# Altium schematic sheet Power.SchDoc
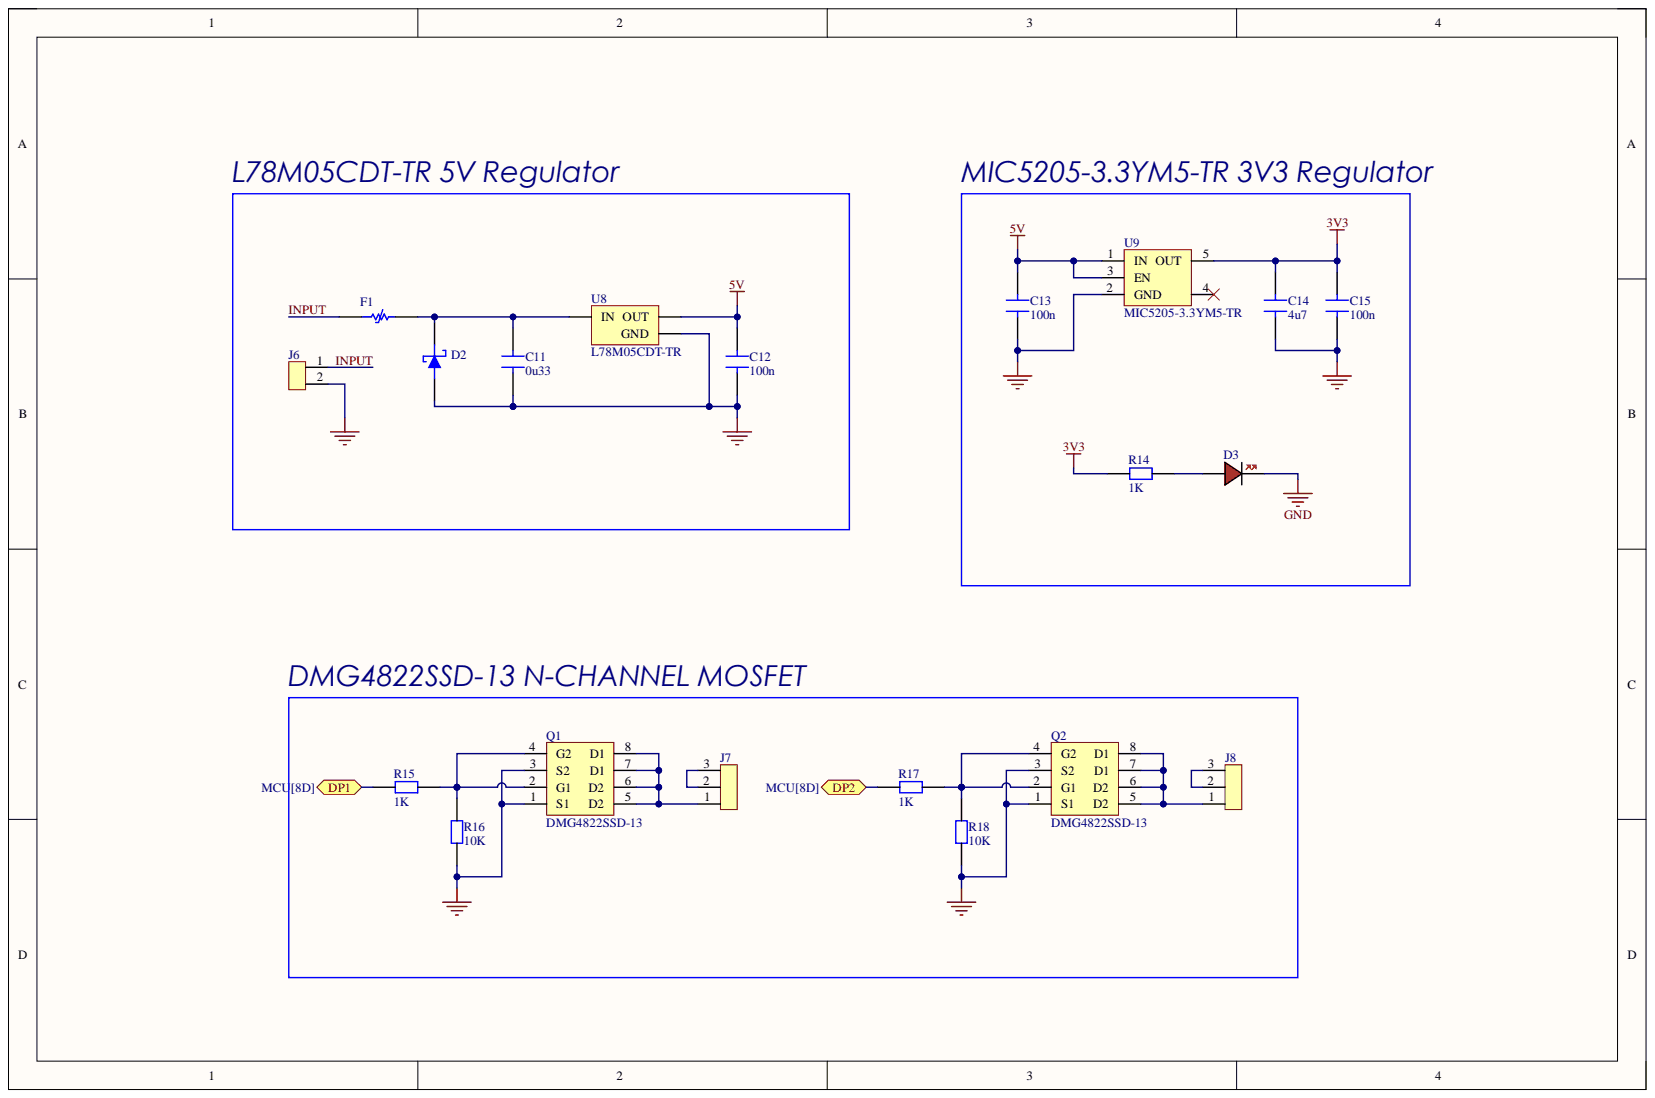
[diagram p0: Power.SchDoc]

## Parts
C11  0u33  [CAP 0805]
C12  100n  [CAP 0805]
C13  100n  [CAP 0805]
C14  4u7  [CAP 0805]
C15  100n  [CAP 0805]
D2  SMBJ12CA-13-F  [SMBJ12CA-13-F]  (value not drawn)
D3  LED 0805 RED  [LED 0805 RED]  (value not drawn)
F1  0ZCG0075FF2C  [0ZCG0075FF2C]  (value not drawn)
J6  KF301 2P Terminal  [KF301 2P]  (value not drawn)
J7  KF301 3P Terminal  [KF301 3P]  (value not drawn)
J8  KF301 3P Terminal  [KF301 3P]  (value not drawn)
Q1  DMG4822SSD-13  [DMG4822SSD-13]
Q2  DMG4822SSD-13  [DMG4822SSD-13]
R14  1K  [RES 0603]
R15  1K  [RES 0603]
R16  10K  [RES 0603]
R17  1K  [RES 0603]
R18  10K  [RES 0603]
U8  L78M05CDT-TR  [L78M05CDT-TR]
U9  MIC5205-3.3YM5-TR  [MIC5205-3.3YM5-TR]

## Ports
DP1, DP2

## Nets
3V3: C14.2 C15.2 R14.1 U9.5(OUT)
5V: C12.2 C13.2 U8.3 U9.1(IN) U9.3(EN)
GND: C11.1 C12.1 C13.1 C14.1 C15.1 D2.1 D3.K J6.2 Q1.1(S1) Q1.3(S2) Q2.1(S1) Q2.3(S2) R16.1 R18.1 U8.2 U9.2(GND)
DP1: R15.1 ; port DP1
DP2: R17.1 ; port DP2
INPUT: F1.1 J6.1
NetC11_2: C11.2 D2.2 F1.2 U8.1
NetD3_A: D3.A R14.2
NetJ7_1: J7.1 Q1.5(D2) Q1.6(D2) Q1.7(D1) Q1.8(D1)
NetJ7_2: J7.2 J7.3
NetJ8_1: J8.1 Q2.5(D2) Q2.6(D2) Q2.7(D1) Q2.8(D1)
NetJ8_2: J8.2 J8.3
NetQ1_2: Q1.2(G1) Q1.4(G2) R15.2 R16.2
NetQ2_2: Q2.2(G1) Q2.4(G2) R17.2 R18.2

Not connected: U9 4

## Library data of the parts
C11-C15 = CAP 0805 50V: Capacitor SMD 0805 50V; Component Kind: Standard; Library Name: Schlib.SchLib
D2 = SMBJ12CA-13-F: TVS DIODE 12VWM 19.9VC SMB; Manufacturer: Diodes; Breakdown Voltage: 15.3V; Capacitance: 340pF; Clamping Voltage: 19.9V; Diode Element Material: SILICON; Diode Type: ZENER; Direction: Bidirectional; Leakage Current: 5uA; Max Output Current: 30.2A; Max Power Dissipation: 600W; Min Breakdown Voltage: 13.3V; Number of Bidirectional Channels: 1; Package Body Material: Plastic; Power Line Protection: No; Reverse Standoff Voltage: 12V; Test Current: 1mA; Time @ Peak Reflow Temperature-Max (s): 5s; Case/Package: SMB; Category: TVS Diodes; Clamping Voltage-Max: 19.9V; Component Kind: Standard; ComponentLink1Description: https://datasheet.ciiva.com/34302/1542303-34302437.pdf?src-supplier=Jameco; ComponentLink1URL: https://datasheet.ciiva.com/34302/1542303-34302437.pdf?src-supplier=Jameco; Height: 2.62mm; JESD-30 Code: R-PDSO-C2; Lead Free: Contains Lead; Length: 4.57mm; Library Name: Schlib.SchLib; Manufacturer Part Number: SMBJ12CA-13-F; Max Breakdown Voltage: 15.3V; Max Operating Temperature: 150°C; Max Reverse Leakage Current: 5uA; Max Surge Current: 30.2A; Min Operating Temperature: -55°C; Moisture Sensitivity Level: 1; Mount: Surface Mount; Number of Elements: 1; Number of Pins: 2; Package Quantity: 3000; Package Shape: Rectangular; Packaging: Tape and Reel; Peak Pulse Current: 30.2A; Peak Pulse Power: 600W; Peak Reflow Temperature (Cel): 245°C; Polarity: Bidirectional; Power Dissipation: 600W; Pricing 1: 3000=0,104, 9000=0,0995, 12000=0,0985, 30000=0,0953, 45000=0,0922 (USD); Radiation Hardening: No; Reverse Breakdown Voltage: 13.3V; RoHS: Yes; RoHS Compliant: Yes; Series: SMBJ; Stock 1: 27000; Supplier 1: Future Electronics; Surface Mount: Yes; Technology: AVALANCHE; Terminal Finish: Tin; Terminal Form: C BEND; Terminal Position: DUAL; Termination: SMD/SMT; Voltage Rating (DC): 12V; Width: 3.9mm; Working Voltage: 12V
D3 = LED 0805 RED: LED 0805 RED SMD; Component Kind: Standard; Library Name: Schlib.SchLib
F1 = 0ZCG0075FF2C: PTC Resettable Fuse 0.75A(hold) 1.5A(trip) 16VDC 100A 0.8W 0.2s 0.11Ohm SMD Solder Pad 1812 4.73 X 3.41 X 0.65mm T/R...; Manufacturer: Bel; Hold Current: 750mA; Time to Trip: 200ms; Case Code (Metric): 4532; Case Code (Imperial): 1812; Case/Package: 1812; Category: PTC Resettable Fuses; Component Kind: Standard; ComponentLink1Description: https://datasheet.ciiva.com/18550/0zcg-18550523.pdf?src-supplier=Digi-Key; ComponentLink1URL: https://datasheet.ciiva.com/18550/0zcg-18550523.pdf?src-supplier=Digi-Key; ComponentLink2Description: https://datasheet.ciiva.com/18550/0zcg-18550524.pdf?src-supplier=Digi-Key; ComponentLink2URL: https://datasheet.ciiva.com/18550/0zcg-18550524.pdf?src-supplier=Digi-Key; ComponentLink3Description: https://datasheet.ciiva.com/18550/0zcg-18550767.pdf?src-supplier=Digi-Key; ComponentLink3URL: https://datasheet.ciiva.com/18550/0zcg-18550767.pdf?src-supplier=Digi-Key; Contact Plating: Tin,Matte; Lead Free: Lead Free; Library Name: Schlib.SchLib; Manufacturer Part Number: 0ZCG0075FF2C; Max Current Rating: 100A; Max Operating Temperature: 85°C; Min Operating Temperature: -40°C; Mount: Surface Mount; Packaging: Cut Tape; Pricing 1: 2000=0,0751, 4000=0,0699, 6000=0,065, 10000=0,0604 (USD); RoHS: Yes; RoHS Compliant: Yes; Series: 0ZCG; Stock 1: 4661; Supplier 1: Arrow Electronics; Trip Current: 1.5A
J6 = KF301 2P Terminal: 2 Pin Screw Terminal Block 5mm; Component Kind: Standard; Library Name: Schlib.SchLib
J7 J8 = KF301 3P Terminal: 3 Pin Screw Terminal Block 5mm; Component Kind: Standard; Library Name: Schlib.SchLib
Q1 Q2 = DMG4822SSD-13: Dual N-Channel 30 V 1.42 W 10.5 nC Enhancement Mode Mosfet - SOIC-8; Manufacturer: Diodes; Continuous Drain Current (ID): 10A; Drain to Source Resistance: 20mR; Drain to Source Voltage (Vdss): 30V; Fall Time: 3.1ns; FET Type: 2 N-Channel; Gate to Source Voltage (Vgs): 25V; Max Power Dissipation: 1.42W; Number of Channels: 2; Rise Time: 7.9ns; Turn-Off Delay Time: 14.6ns; Turn-On Delay Time: 2.9ns; Input Capacitance: 478.9pF; Case/Package: SOIC; Category: MOSFETs; Component Kind: Standard; ComponentLink1Description: https://datasheet.ciiva.com/4443/dmg4822ssd-10758-4443079.pdf?src-supplier=Mouser; ComponentLink1URL: https://datasheet.ciiva.com/4443/dmg4822ssd-10758-4443079.pdf?src-supplier=Mouser; ComponentLink2Description: https://datasheet.ciiva.com/6386/dmg4822ssd-6386688.pdf?src-supplier=Digi-Key; ComponentLink2URL: https://datasheet.ciiva.com/6386/dmg4822ssd-6386688.pdf?src-supplier=Digi-Key; ComponentLink3Description: https://datasheet.ciiva.com/11174/dmg4822ssd-11174891.pdf?src-supplier=Avnet; ComponentLink3URL: https://datasheet.ciiva.com/11174/dmg4822ssd-11174891.pdf?src-supplier=Avnet; Height: 1.5mm; Lead Free: Lead Free; Length: 4.95mm; Library Name: Schlib.SchLib; Manufacturer Part Number: DMG4822SSD-13; Max Operating Temperature: 150°C; Min Operating Temperature: -55°C; Mount: Surface Mount; Number of Pins: 8; Package Quantity: 2500; Packaging: Tape and Reel; Polarity: N-CHANNEL; Pricing 1: 1=0,5556, 10=0,4998, 25=0,4948, 100=0,3987, 250=0,3947, 500=0,3232, 1000=0,265 (USD); Radiation Hardening: No; Rds On Max: 20mR; Reach SVHC Compliant: Yes; RoHS: Yes; RoHS Compliant: Yes; Series: -; Stock 1: 2374; Supplier 1: Arrow Electronics; Weight: 0.00261oz; Width: 3.95mm
R14-R18 = RES 0603: Resistor SMD 0603; Component Kind: Standard; Library Name: Schlib.SchLib
U8 = L78M05CDT-TR: IC REG LDO 5V 0.5A DPAK; Manufacturer: STMicroelectronics; Dropout Voltage: 2V; Max Output Current: 500mA; Max Input Voltage: 35V; Min Input Voltage: 7V; Number of Outputs: 1; Output Configuration: Positive; Output Type: Fixed; Power Supply Rejection Ratio (PSRR): 62dB; Quiescent Current: 6mA; Tolerance: 5%; Case/Package: TO-252; Category: Voltage Regulators - Linear; Component Kind: Standard; ComponentLink1Description: https://datasheet.ciiva.com/34303/2021024-34303902.pdf?src-supplier=Jameco; ComponentLink1URL: https://datasheet.ciiva.com/34303/2021024-34303902.pdf?src-supplier=Jameco; ComponentLink2Description: https://datasheet.ciiva.com/4882/cd00000447-93067-4882369.pdf?src-supplier=Mouser; ComponentLink2URL: https://datasheet.ciiva.com/4882/cd00000447-93067-4882369.pdf?src-supplier=Mouser; ComponentLink3Description: https://datasheet.ciiva.com/15111/getdatasheetpartid-111001-15111751.pdf?src-supplier=Verical; ComponentLink3URL: https://datasheet.ciiva.com/15111/getdatasheetpartid-111001-15111751.pdf?src-supplier=Verical; ComponentLink4Description: https://datasheet.ciiva.com/1512/1834365-1512349.pdf?src-supplier=Element14; ComponentLink4URL: https://datasheet.ciiva.com/1512/1834365-1512349.pdf?src-supplier=Element14; ComponentLink5Description: https://datasheet.ciiva.com/14111/tbl78mxxc-data-e-14111354.pdf?src-supplier=Distrelec; ComponentLink5URL: https://datasheet.ciiva.com/14111/tbl78mxxc-data-e-14111354.pdf?src-supplier=Distrelec; ComponentLink6Description: https://datasheet.ciiva.com/46425/1834365-46425535.pdf?src-supplier=Element14; ComponentLink6URL: https://datasheet.ciiva.com/46425/1834365-46425535.pdf?src-supplier=Element14; ComponentLink7Description: https://datasheet.ciiva.com/2041/cd00000447-2041991.pdf?src-supplier=Digi-Key; ComponentLink7URL: https://datasheet.ciiva.com/2041/cd00000447-2041991.pdf?src-supplier=Digi-Key; ComponentLink8Description: https://datasheet.ciiva.com/2041/cd00000447-2041990.pdf?src-supplier=Digi-Key; ComponentLink8URL: https://datasheet.ciiva.com/2041/cd00000447-2041990.pdf?src-supplier=Digi-Key; ComponentLink9Description: https://datasheet.ciiva.com/2041/cd00000447-2041992.pdf?src-supplier=Digi-Key; ComponentLink9URL: https://datasheet.ciiva.com/2041/cd00000447-2041992.pdf?src-supplier=Digi-Key; ComponentLink10Description: https://datasheet.ciiva.com/16443/cd00000447-16443381.pdf?src-supplier=Future+Electronics; ComponentLink10URL: https://datasheet.ciiva.com/16443/cd00000447-16443381.pdf?src-supplier=Future+Electronics; ComponentLink11Description: https://datasheet.ciiva.com/41439/001184659-da-01-en-ic-reg-ldo-5v-l78m05cdt-tr-to-252-3-stm-41439772.pdf?src-supplier=Conrad; ComponentLink11URL: https://datasheet.ciiva.com/41439/001184659-da-01-en-ic-reg-ldo-5v-l78m05cdt-tr-to-252-3-stm-41439772.pdf?src-supplier=Conrad; Height: 2.4mm; Lead Free: Lead Free; Length: 6.6mm; Library Name: Schlib.SchLib; Manufacturer Part Number: L78M05CDT-TR; Max Operating Temperature: 150°C; Max Output Voltage: 5V; Min Operating Temperature: 0°C; Min Output Voltage: 5V; Mount: Surface Mount; Nominal Output Voltage: 5V; Number of Pins: 3; Number of Regulators: 1; Output Current: 500mA; Output Voltage: 5V; Package Quantity: 2500; Packaging: Tape and Reel; Polarity: Positive; Pricing 1: 1=0,72, 10=0,629, 50=0,629, 100=0,482, 1000=0,305, 10000=0,277 (USD); Radiation Hardening: No; Reach SVHC Compliant: No; RoHS: Yes; RoHS Compliant: Yes; Series: -; Stock 1: 74380; Supplier 1: Mouser; Width: 6.2mm
U9 = MIC5205-3.3YM5-TR: Linear Voltage Regulator IC Positive Fixed 1 Output 3.3V 150mA SOT-23-5; Manufacturer: Microchip; Accuracy: 1%; Dropout Voltage: 165mV; Max Supply Voltage: 16V; Min Supply Voltage: 2.5V; Number of Outputs: 1; Power Supply Rejection Ratio (PSRR): 75dB; Case/Package: SOT-23; Category: Voltage Regulators - Linear; Component Kind: Standard; Height: 1.1mm; Lead Free: Lead Free; Length: 2.9mm; Library Name: Schlib.SchLib; Manufacturer Part Number: MIC5205-3.3YM5-TR; Max Operating Temperature: 125°C; Max Output Current: 150mA; Max Output Voltage: 3.3V; Max Input Voltage: 16V; Min Operating Temperature: -40°C; Min Input Voltage: 2.5V; Mount: Surface Mount; Nominal Output Voltage: 3.3V; Number of Pins: 5; Number of Regulators: 1; Output Current: 150mA; Output Type: Fixed; Output Voltage: 3.3V; Output Voltage Accuracy: 1%; Packaging: Tape and Reel; Polarity: Positive; Pricing 1: 1=0,5386, 10=0,5334, 25=0,4417, 50=0,4373, 100=0,395, 250=0,3909, 500=0,3869, 1000=0,3827, 3000=0,3677 (USD); Radiation Hardening: No; Reach SVHC Compliant: No; RoHS: Yes; RoHS Compliant: Yes; Series: -; Stock 1: 2538; Supplier 1: Arrow Electronics; Termination: SMD/SMT; Width: 1.6mm
